# Altium schematic sheet open-rt-pen.SchDoc
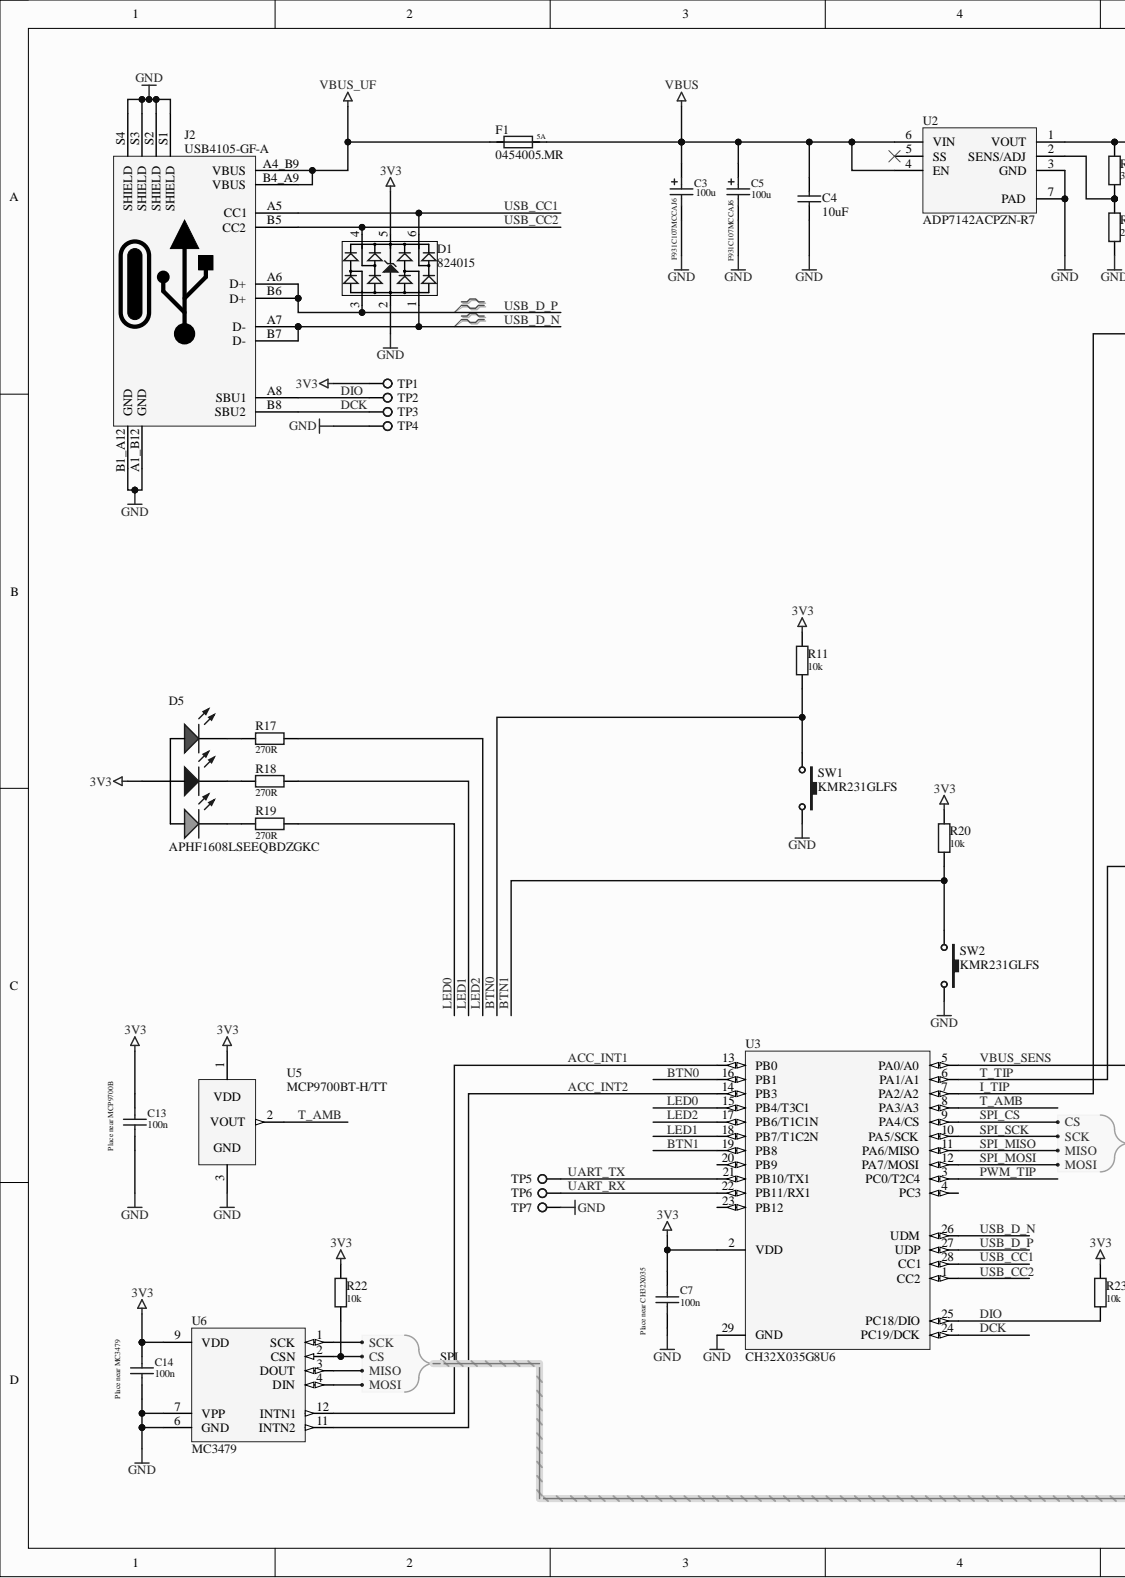
[diagram p0: open-rt-pen.SchDoc, left]
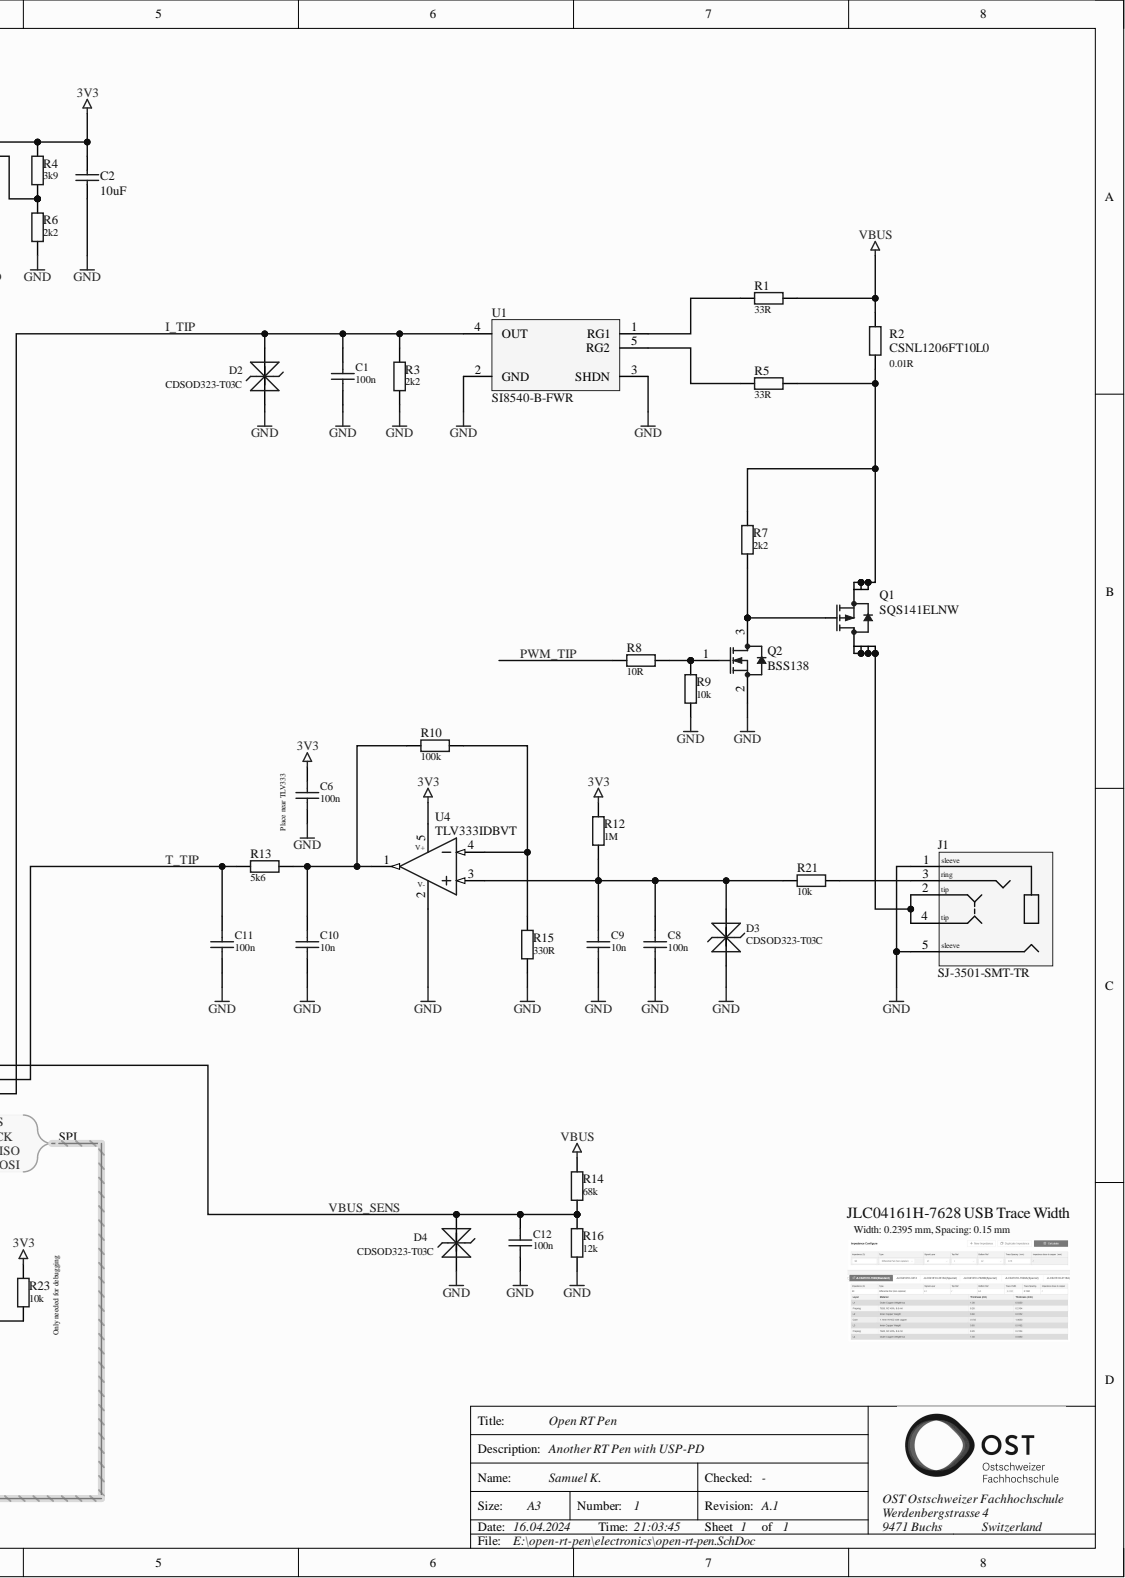
[diagram p1: open-rt-pen.SchDoc, right]

## Parts
C1  value 100n  ShortDescription: 100n  [Generic_Cap0603_2_R]  (hidden comment: 06035C104kAT2A)
C2  ShortDescription: 10uF  [Generic_Cap0603_2_RW]  (hidden comment: GRM188R61E106KA73D)
C3  F931C107MCCAJ6  value 100u  ShortDescription: 100u  [Generic_CPOL_C-2312_1P_2N_2]
C4  ShortDescription: 10uF  [Generic_Cap0603_2_RW]  (hidden comment: GRM188R61E106KA73D)
C5  F931C107MCCAJ6  value 100u  ShortDescription: 100u  [Generic_CPOL_C-2312_1P_2N_2]
C6  value 100n  ShortDescription: 100n  [Generic_Cap0603_2_R]  (hidden comment: 06035C104kAT2A)
C7  value 100n  ShortDescription: 100n  [Generic_Cap0603_2_R]  (hidden comment: 06035C104kAT2A)
C8  value 100n  ShortDescription: 100n  [Generic_Cap0603_2_R]  (hidden comment: 06035C104kAT2A)
C9  value 10n  ShortDescription: 10n  [Generic_Cap0603_2_R]  (hidden comment: 06035C103kAT2A)
C10  value 10n  ShortDescription: 10n  [Generic_Cap0603_2_R]  (hidden comment: 06035C103kAT2A)
C11  value 100n  ShortDescription: 100n  [Generic_Cap0603_2_R]  (hidden comment: 06035C104kAT2A)
C12  value 100n  ShortDescription: 100n  [Generic_Cap0603_2_R]  (hidden comment: 06035C104kAT2A)
C13  value 100n  ShortDescription: 100n  [Generic_Cap0603_2_R]  (hidden comment: 06035C104kAT2A)
C14  value 100n  ShortDescription: 100n  [Generic_Cap0603_2_R]  (hidden comment: 06035C104kAT2A)
D1  824015  [GENERIC_SOT23-6_6_R]
D2  CDSOD323-T03C  [bourns_SOD323_2]
D3  CDSOD323-T03C  [bourns_SOD323_2]
D4  CDSOD323-T03C  [bourns_SOD323_2]
D5  APHF1608LSEEQBDZGKC  [Kingsbright_RGB_LED_0603_RH]
F1  0454005.MR  ShortDescription: 5A  [LITTLEFUSE_045401.5MR_2_R]
J1  SJ-3501-SMT-TR  [CUI_SJ-3501-SMT-TR_5]
J2  USB4105-GF-A  [GCT_USB4105-GF-A_16_R]
Q1  SQS141ELNW  [Vishay_PowerPAK_1212-8_8]
Q2  BSS138  [Generic_SOT23_3_RWH]
R1  value 33R  ShortDescription: 33R  [Generic_Res0603_2_RW]  (hidden comment: RC0603FR-0733RL)
R2  CSNL1206FT10L0  value 0.01R  ShortDescription: 0.01R  [Generic_Res1206_2_RW]
R3  value 2k2  ShortDescription: 2k2  [Generic_Res0603_2_RW]  (hidden comment: RC0603FR-072K2L)
R4  value 3k9  ShortDescription: 3k9  [Generic_Res0603_2_RW]  (hidden comment: RC0603FR-073K9L)
R5  value 33R  ShortDescription: 33R  [Generic_Res0603_2_RW]  (hidden comment: RC0603FR-0733RL)
R6  value 2k2  ShortDescription: 2k2  [Generic_Res0603_2_RW]  (hidden comment: RC0603FR-072K2L)
R7  value 2k2  ShortDescription: 2k2  [Generic_Res0603_2_RW]  (hidden comment: RC0603FR-072K2L)
R8  value 10R  ShortDescription: 10R  [Generic_Res0603_2_RW]  (hidden comment: RC0603FR-0710RL)
R9  value 10k  ShortDescription: 10k  [Generic_Res0603_2_RW]  (hidden comment: RC0603FR-0710KL)
R10  value 100k  ShortDescription: 100k  [Generic_Res0603_2_RW]  (hidden comment: RC0603FR-07100KL)
R11  value 10k  ShortDescription: 10k  [Generic_Res0603_2_RW]  (hidden comment: RC0603FR-0710KL)
R12  value 1M  ShortDescription: 1M  [Generic_Res0603_2_RW]  (hidden comment: RC0603FR-071ML)
R13  value 5k6  ShortDescription: 5k6  [Generic_Res0603_2_RW]  (hidden comment: RC0603FR-075K6L)
R14  value 68k  ShortDescription: 68k  [Generic_Res0603_2_RW]  (hidden comment: RC0603FR-0768KL)
R15  value 330R  ShortDescription: 330R  [Generic_Res0603_2_RW]  (hidden comment: RC0603FR-07330RL)
R16  value 12k  ShortDescription: 12k  [Generic_Res0603_2_RW]  (hidden comment: RC0603FR-0712KL)
R17  value 270R  ShortDescription: 270R  [Generic_Res0603_2_RW]  (hidden comment: RC0603FR-07270RL)
R18  value 270R  ShortDescription: 270R  [Generic_Res0603_2_RW]  (hidden comment: RC0603FR-07270RL)
R19  value 270R  ShortDescription: 270R  [Generic_Res0603_2_RW]  (hidden comment: RC0603FR-07270RL)
R20  value 10k  ShortDescription: 10k  [Generic_Res0603_2_RW]  (hidden comment: RC0603FR-0710KL)
R21  value 10k  ShortDescription: 10k  [Generic_Res0603_2_RW]  (hidden comment: RC0603FR-0710KL)
R22  value 10k  ShortDescription: 10k  [Generic_Res0603_2_RW]  (hidden comment: RC0603FR-0710KL)
R23  value 10k  ShortDescription: 10k  [Generic_Res0603_2_RW]  (hidden comment: RC0603FR-0710KL)
SW1  KMR231GLFS  [CK_KMR231GLFS_4]
SW2  KMR231GLFS  [CK_KMR231GLFS_4]
TP1  Testpoint 1.5mm  [Generic_SolderPad-1.5x1.5R_1_H]  (value not drawn)
TP2  Testpoint 1.5mm  [Generic_SolderPad-1.5x1.5R_1_H]  (value not drawn)
TP3  Testpoint 1.5mm  [Generic_SolderPad-1.5x1.5R_1_H]  (value not drawn)
TP4  Testpoint 1.5mm  [Generic_SolderPad-1.5x1.5R_1_H]  (value not drawn)
TP5  Testpoint 1.5mm  [Generic_SolderPad-1.5x1.5R_1_H]  (value not drawn)
TP6  Testpoint 1.5mm  [Generic_SolderPad-1.5x1.5R_1_H]  (value not drawn)
TP7  Testpoint 1.5mm  [Generic_SolderPad-1.5x1.5R_1_H]  (value not drawn)
U1  SI8540-B-FWR  [GENERIC_SOT23-5_5_R]
U2  ADP7142ACPZN-R7  [ANALOG_LFCSP6_7_R]
U3  CH32X035G8U6  [WCH_QFN28_29]
U4  TLV333IDBVT  [TI_SOT23_5_RH]
U5  MCP9700BT-H/TT  [Generic_SOT23_3_R]
U6  MC3479  [MEMSIC_MC3479_LGA12_12_R]

## Nets
3V3: C2.2 C6.2 C7.2 C13.2 C14.2 D1.5 D5.1 R4.1 R11.1 R12.1 R20.1 R22.2 R23.2 TP1.1 U2.1(VOUT) U3.2(VDD) U4.5 U5.1 U6.9(VDD)
GND: C1.1 C2.1 C3.2 C4.1 C5.2 C6.1 C7.1 C8.1 C9.1 C10.1 C11.1 C12.1 C13.1 C14.1 D1.2 D2.2 D3.2 D4.2 J1.1 J1.5 J2.A1_B12(GND) J2.B1_A12(GND) J2.S1(SHIELD) J2.S2(SHIELD) J2.S3(SHIELD) J2.S4(SHIELD) Q2.2 R3.2 R6.2 R9.2 R15.1 R16.2 SW1.1 SW2.1 TP4.1 TP7.1 U1.2(GND) U1.3(SHDN) U2.3(GND) U2.7(PAD) U3.29(GND) U4.2 U5.3 U6.6(GND) U6.7(VPP)
VBUS: C3.1 C4.2 C5.1 F1.2 R1.2 R2.1 R14.1 U2.4(EN) U2.6(VIN)
VBUS_UF: F1.1 J2.A4_B9(VBUS) J2.B4_A9(VBUS)
ACC_INT1: U3.13(PB0) U6.12(INTN1)
ACC_INT2: U3.14(PB3) U6.11(INTN2)
BTN0: R11.2 SW1.2 U3.16(PB1)
BTN1: R20.2 SW2.2 U3.19(PB8)
DCK: J2.B8(SBU2) TP3.1 U3.24(PC19/DCK)
DIO: J2.A8(SBU1) R23.1 TP2.1 U3.25(PC18/DIO)
I_TIP: C1.2 D2.1 R3.1 U1.4(OUT) U3.7(PA2/A2)
LED0: R19.1 U3.15(PB4/T3C1)
LED1: R18.1 U3.18(PB7/T1C2N)
LED2: R17.1 U3.17(PB6/T1C1N)
PWM_TIP: R8.1 U3.3(PC0/T2C4)
SPI_CS: R22.1 U3.9(PA4/CS) U6.2(CSN)
SPI_MISO: U3.11(PA6/MISO) U6.3(DOUT)
SPI_MOSI: U3.12(PA7/MOSI) U6.4(DIN)
SPI_SCK: U3.10(PA5/SCK) U6.1(SCK)
T_AMB: U3.8(PA3/A3) U5.2
T_TIP: C11.2 R13.1 U3.6(PA1/A1)
UART_RX: TP6.1 U3.22(PB11/RX1)
UART_TX: TP5.1 U3.21(PB10/TX1)
USB_CC1: D1.6 J2.A5(CC1) U3.28(CC1)
USB_CC2: D1.4 J2.B5(CC2) U3.1(CC2)
USB_D_N: D1.1 J2.A7(D-) J2.B7(D-) U3.26(UDM)
USB_D_P: D1.3 J2.A6(D+) J2.B6(D+) U3.27(UDP)
VBUS_SENS: C12.2 D4.1 R14.2 R16.1 U3.5(PA0/A0)
NetC8_2: C8.2 C9.2 D3.1 R12.2 R21.2 U4.3
NetC10_2: C10.2 R10.2 R13.2 U4.1
NetD5_2: D5.2 R17.2
NetD5_3: D5.3 R18.2
NetD5_4: D5.4 R19.2
NetJ1_2: J1.2 J1.4 Q1.5 Q1.6 Q1.7 Q1.8
NetJ1_3: J1.3 R21.1
NetQ1_1: Q1.1 Q1.2 Q1.3 R2.2 R5.2 R7.1
NetQ1_4: Q1.4 Q2.3 R7.2
NetQ2_1: Q2.1 R8.2 R9.1
NetR1_1: R1.1 U1.1(RG1)
NetR4_2: R4.2 R6.1 U2.2(SENS/ADJ)
NetR5_1: R5.1 U1.5(RG2)
NetR10_1: R10.1 R15.2 U4.4

Not connected: U2 5(SS); U3 4(PC3) 20(PB9) 23(PB12)

## Library data of the parts
C1 C6-C8 C11-C14 = KYOCERA_AVX_X7R-DIELECTRIC-0000-A.1: 100n, X7R, 10%, 50V, 0603; Manufacturer: Kyocera AVX; Component Kind: Standard; Manufacturer PartNr: 06035C104kAT2A; Library Name: OST Vault
C2 C4 = Baugruppenfertigung-0041-A.5: 10uF, X5R, 10%, 25V; Manufacturer: Murata; Component Kind: Standard; Manufacturer PartNr: GRM188R61E106KA73D; Library Name: OST Vault
C3 C5 = KRSA-0126-A.1: 100u, TANTAL, 20%, 16V, C(2312); Manufacturer: AVX; Component Kind: Standard; Manufacturer PartNr: F931C107MCCAJ6; Library Name: OST Vault
C9 C10 = KYOCERA_AVX_X7R-DIELECTRIC-0001-A.2: 10n, X7R, 10%, 50V, 0603; Manufacturer: Kyocera AVX; Component Kind: Standard; Manufacturer PartNr: 06035C103kAT2A; Library Name: OST Vault
D1 = KRSA-0098-A.1: TVS Diode Array, 5V, Unidirectional; Manufacturer: Wurth; Component Kind: Standard; Manufacturer PartNr: 824015; ShortDescription: 824015; Library Name: OST Vault
D2-D4 = 4.6520.059.01_Hilti_Rebar_Detector-0042-A.1: TVS Diode, bidirectional, 3V3, 1pF; Manufacturer: bourns; ShortDescription: T03C; Component Kind: Standard; Manufacturer PartNr: CDSOD323-T03C; Library Name: OST Vault
D5 = Component-00142-A.1: LED RGB 0603 SMD; Manufacturer: Kingsbright; ShortDescription: Description (used in sheet); Component Kind: Standard; Manufacturer PartNr: APHF1608LSEEQBDZGKC; Library Name: OST Vault
F1 = 4.6520.020_Palindrome_RTSDrone-0335-A.1: Nano Slo-Blo, Surface Mount Fuse, 5A; Manufacturer: Littlefuse; Component Kind: Standard; Manufacturer PartNr: 0454005.MR; Library Name: OST Vault
J1 = KRSA-0117-A.1: Audio Jack, 3.5mm, Mid Mount; Manufacturer: CUI; Component Kind: Standard; Manufacturer PartNr: SJ-3501-SMT-TR; ShortDescription: SJ-3501-SMT-TR; Library Name: OST Vault
J2 = KRSA-0082-A.1: USB Type C, Edge Mount, USB 2.0; Manufacturer: GCT; ShortDescription: USB-C; Component Kind: Standard; Manufacturer PartNr: USB4105-GF-A; Library Name: OST Vault
Q1 = KRSA-0111-A.1: MOSFET, P-Ch, 40V, 100A; Manufacturer: Vishay; Component Kind: Standard; Manufacturer PartNr: SQS141ELNW; ShortDescription: SQS141ELNW; Library Name: OST Vault
Q2 = 00000_Hilti_Localisation_MA-0139-A.4: MOSFET, N-Ch, 50V, 220mA; Manufacturer: ON; Component Kind: Standard; Manufacturer PartNr: BSS138; ShortDescription: BSS138; Library Name: OST Vault
R1 R5 = YAEGO_RC0603FR-07-0019-A.2: 33R, 1%, 0.1W, 0603; Manufacturer: YAGEO; Component Kind: Standard; Manufacturer PartNr: RC0603FR-0733RL; Library Name: OST Vault
R2 = KRSA-0128-A.1: 0.01R, Shunt, 1W, 1%, 1206; Manufacturer: Stackpole Electronics Inc; Component Kind: Standard; Manufacturer PartNr: CSNL1206FT10L0; Library Name: OST Vault
R3 R6 R7 = YAEGO_RC0603FR-07-0041-A.2: 2k2, 1%, 0.1W, 0603; Manufacturer: YAGEO; Component Kind: Standard; Manufacturer PartNr: RC0603FR-072K2L; Library Name: OST Vault
R4 = YAEGO_RC0603FR-07-0044-A.1: 3k9, 1%, 0.1W, 0603; Manufacturer: YAGEO; Component Kind: Standard; Manufacturer PartNr: RC0603FR-073K9L; Library Name: OST Vault
R8 = YAEGO_RC0603FR-07-0013-A.2: 10R, 1%, 0.1W, 0603; Manufacturer: YAGEO; Component Kind: Standard; Manufacturer PartNr: RC0603FR-0710RL; Library Name: OST Vault
R9 R11 R20-R23 = YAEGO_RC0603FR-07-0049-A.1: 10k, 1%, 0.1W, 0603; Manufacturer: YAGEO; Component Kind: Standard; Manufacturer PartNr: RC0603FR-0710KL; Library Name: OST Vault
R10 = YAEGO_RC0603FR-07-0061-A.1: 100k, 1%, 0.1W, 0603; Manufacturer: YAGEO; Component Kind: Standard; Manufacturer PartNr: RC0603FR-07100KL; Library Name: OST Vault
R12 = YAEGO_RC0603FR-07-0073-A.1: 1M, 1%, 0.1W, 0603; Manufacturer: YAGEO; Component Kind: Standard; Manufacturer PartNr: RC0603FR-071ML; Library Name: OST Vault
R13 = YAEGO_RC0603FR-07-0046-A.1: 5k6, 1%, 0.1W, 0603; Manufacturer: YAGEO; Component Kind: Standard; Manufacturer PartNr: RC0603FR-075K6L; Library Name: OST Vault
R14 = YAEGO_RC0603FR-07-0059-A.1: 68k, 1%, 0.1W, 0603; Manufacturer: YAGEO; Component Kind: Standard; Manufacturer PartNr: RC0603FR-0768KL; Library Name: OST Vault
R15 = YAEGO_RC0603FR-07-0031-A.2: 330R, 1%, 0.1W, 0603; Manufacturer: YAGEO; Component Kind: Standard; Manufacturer PartNr: RC0603FR-07330RL; Library Name: OST Vault
R16 = YAEGO_RC0603FR-07-0050-A.1: 12k, 1%, 0.1W, 0603; Manufacturer: YAGEO; Component Kind: Standard; Manufacturer PartNr: RC0603FR-0712KL; Library Name: OST Vault
R17-R19 = YAEGO_RC0603FR-07-0030-A.2: 270R, 1%, 0.1W, 0603; Manufacturer: YAGEO; Component Kind: Standard; Manufacturer PartNr: RC0603FR-07270RL; Library Name: OST Vault
SW1 SW2 = 10707_OST_EuR2_Projekt-0018-A.1: Tactile Switch, Thin Type; Manufacturer: CK; Component Kind: Standard; Library Name: OST Vault; Manufacturer PartNr: KMR231GLFS; ShortDescription: KMR231GLFS
TP1-TP7 = KRSA-0230-A.1: Testpoint, round, 1.5mm; Manufacturer: Generic; Component Kind: Standard; Manufacturer PartNr: -; ShortDescription: Testpoin; Library Name: OST Vault
U1 = KRSA-0131-A.1: High-side Current Sense Amplifier; Manufacturer: Skyworks; ShortDescription: SI8540; Component Kind: Standard; Manufacturer PartNr: SI8540-B-FWR; Library Name: OST Vault
U2 = KRSA-0055-A.2: 40 V, 200 mA, Low Noise, ADJ CMOS LDO Linear Regulator; Manufacturer: Analog; Component Kind: Standard; Manufacturer PartNr: ADP7142ACPZN-R7; ShortDescription: ADP7142; Library Name: OST Vault
U3 = KRSA-0178-A.3: WCH CH32, USB, USB-PD, I2C, SPI, UART, OPA, CMP, ADC, TOUCH; Component Kind: Standard; Manufacturer: WCH; Manufacturer PartNr: CH32X035G8U6; ShortDescription: CH32X035G8U6; Library Name: OST Vault
U4 = KRSA-0108-A.1: 350kHz GBW, Low Noise, Zero Drift, 5.5V; Manufacturer: TI; ShortDescription: TLV333; Component Kind: Standard; Manufacturer PartNr: TLV333IDBVT; Library Name: OST Vault
U5 = KRSA-0242-A.1: Temperature Sensor, Analog, +/- 1°C, SOT23; Manufacturer: Microchip; Component Kind: Standard; Manufacturer PartNr: MCP9700BT-H/TT; ShortDescription: MCP9700BT-H/TT; Library Name: OST Vault
U6 = KRSA-0245-A.1: 3-Axis Accelerometer; Manufacturer: MEMSIC; Component Kind: Standard; Manufacturer PartNr: MC3479; ShortDescription: MC3479; Library Name: OST Vault
